# Altium schematic sheet 01_Shield_Ethernet_V1.0.SchDoc
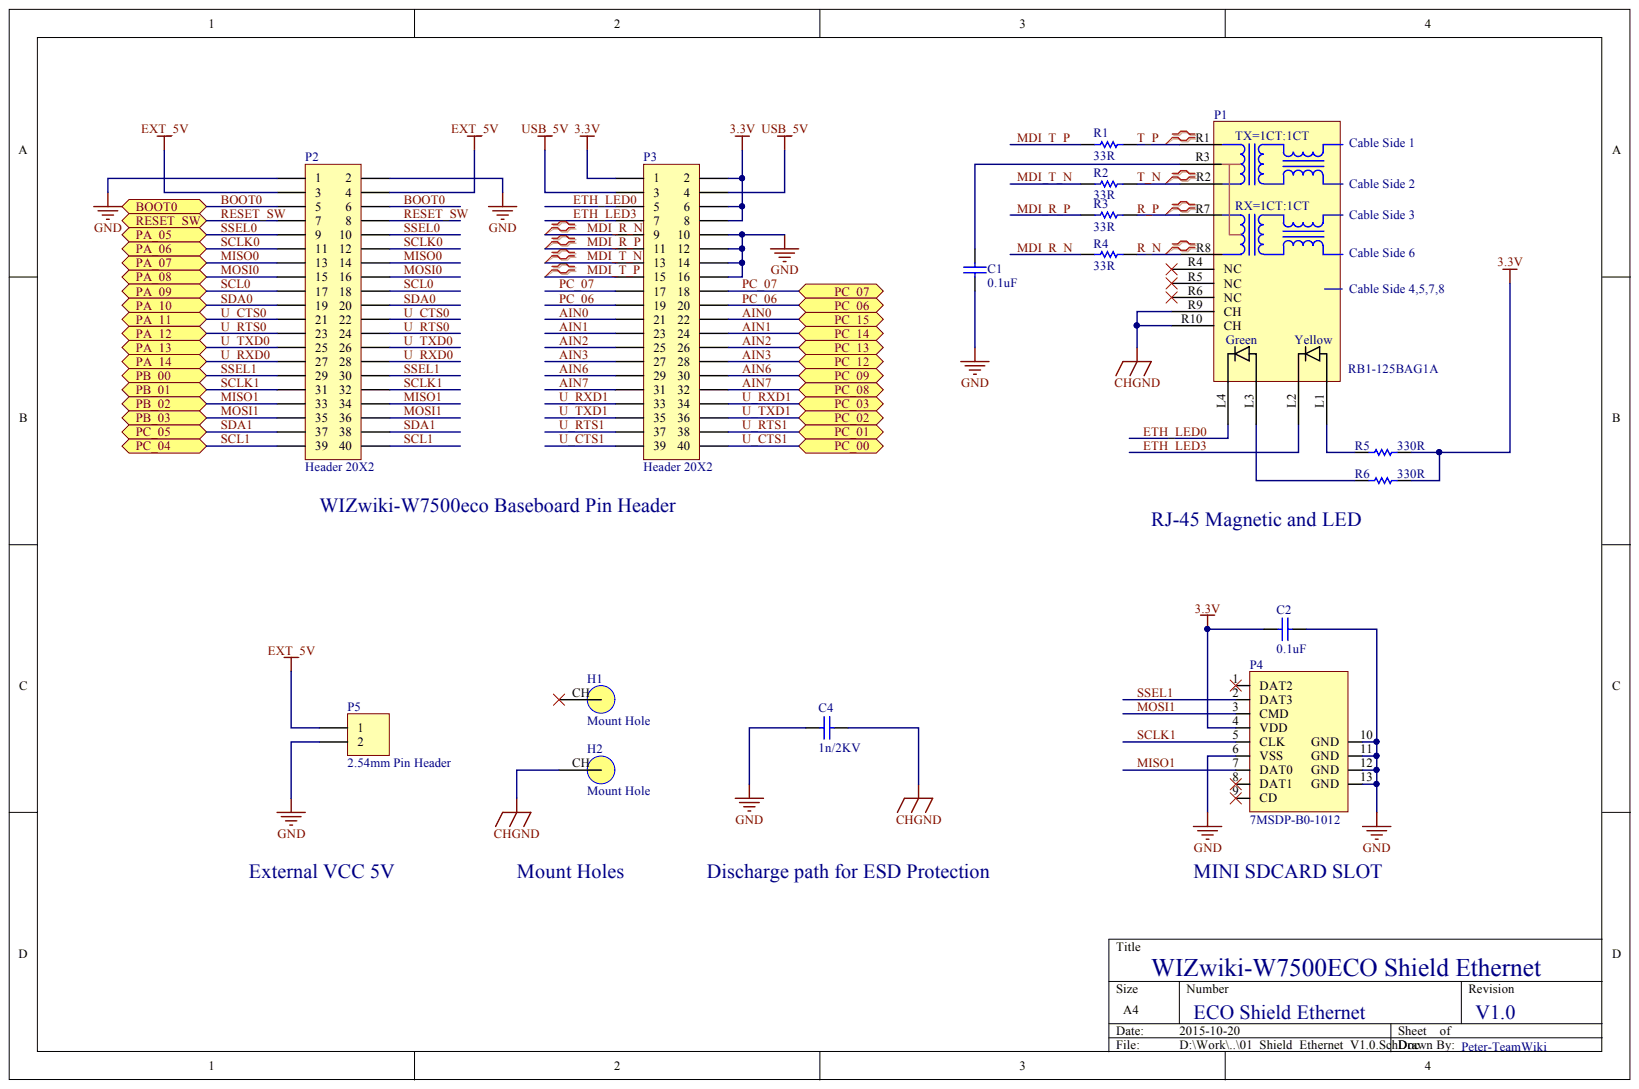
[diagram p0: 01_Shield_Ethernet_V1.0.SchDoc]

## Parts
C1  0.1uF  [CAP-0603]
C2  0.1uF  [CAP-0603]
C4  1n/2KV  [CAP-1808]
H1  Mount Hole
H2  Mount Hole
P1  RB1-125BAG1A  [RJ45-125BAG1A]
P2  Header 20X2  [IDC-2X20]
P3  Header 20X2  [IDC-2X20]
P4  7MSDP-B0-1012  [7MSDP-B0-1012]
P5  2.54mm Pin Header  [IDC-1X2]
R1  33R  [RES-0603]
R2  33R  [RES-0603]
R3  33R  [RES-0603]
R4  33R  [RES-0603]
R5  330R  [RES-0603]
R6  330R  [RES-0603]

## Ports
BOOT0, PA_05, PA_06, PA_07, PA_08, PA_09, PA_10, PA_11, PA_12, PA_13, PA_14, PB_00, PB_01, PB_02, PB_03, PC_00, PC_01, PC_02, PC_03, PC_04, PC_05, PC_06, PC_07, PC_08, PC_09, PC_12, PC_13, PC_14, PC_15, RESET_SW

## Nets
3.3V: C2.2 P3.1 P3.2 P3.6 P3.8 P4.4(VDD) R5.1 R6.1
CHGND: C4.2 H2.CH P1.R9(CH) P1.R10(CH)
EXT_5V: P2.3 P2.4 P5.1
GND: C1.1 C2.1 C4.1 P2.1 P2.2 P3.10 P3.12 P3.14 P3.16 P4.6(VSS) P4.10(GND) P4.11(GND) P4.12(GND) P4.13(GND) P5.2
USB_5V: P3.3 P3.4
AIN0: P3.21 P3.22 ; port PC_15
AIN1: P3.23 P3.24 ; port PC_14
AIN2: P3.25 P3.26 ; port PC_13
AIN3: P3.27 P3.28 ; port PC_12
AIN6: P3.29 P3.30 ; port PC_09
AIN7: P3.31 P3.32 ; port PC_08
BOOT0: P2.5 P2.6 ; port BOOT0
ETH_LED0: P1.L4 P3.5
ETH_LED3: P1.L2 P3.7
MDI_R_N: P3.9 R4.1
MDI_R_P: P3.11 R3.1
MDI_T_N: P3.13 R2.1
MDI_T_P: P3.15 R1.1
MISO0: P2.13 P2.14 ; port PA_07
MISO1: P2.33 P2.34 P4.7(DAT0) ; port PB_02
MOSI0: P2.15 P2.16 ; port PA_08
MOSI1: P2.35 P2.36 P4.3(CMD) ; port PB_03
PC_06: P3.19 P3.20 ; port PC_06
PC_07: P3.17 P3.18 ; port PC_07
R_N: P1.R8 R4.2
R_P: P1.R7 R3.2
RESET_SW: P2.7 P2.8 ; port RESET_SW
SCL0: P2.17 P2.18 ; port PA_09
SCL1: P2.39 P2.40 ; port PC_04
SCLK0: P2.11 P2.12 ; port PA_06
SCLK1: P2.31 P2.32 P4.5(CLK) ; port PB_01
SDA0: P2.19 P2.20 ; port PA_10
SDA1: P2.37 P2.38 ; port PC_05
SSEL0: P2.9 P2.10 ; port PA_05
SSEL1: P2.29 P2.30 P4.2(DAT3) ; port PB_00
T_N: P1.R2 R2.2
T_P: P1.R1 R1.2
U_CTS0: P2.21 P2.22 ; port PA_11
U_CTS1: P3.39 P3.40 ; port PC_00
U_RTS0: P2.23 P2.24 ; port PA_12
U_RTS1: P3.37 P3.38 ; port PC_01
U_RXD0: P2.27 P2.28 ; port PA_14
U_RXD1: P3.33 P3.34 ; port PC_03
U_TXD0: P2.25 P2.26 ; port PA_13
U_TXD1: P3.35 P3.36 ; port PC_02
NetC1_2: C1.2 P1.R3
NetP1_L1: P1.L1 R5.2
NetP1_L3: P1.L3 R6.2

Not connected: H1 CH; P1 R4(NC) R5(NC) R6(NC); P4 1(DAT2) 8(DAT1) 9(CD)

## Library data of the parts
C1 C2 = CAP-0603: Ceramic CAP 0603(1608); Component Kind: Standard; Library Name: Scott_lib.IntLib
C4 = CAP-1808: Ceramic CAP 1808(4520); Component Kind: Standard; Library Name: Scott_lib.IntLib
P1 = RJ-45 MAGNETICS AND LEDS RB1-125BAG1A: Component Kind: Standard; Library Name: peters.SchLib
P2 P3 = Header 20X2: Header, 20-Pin, Dual row, 2.54mm; Component Kind: Standard; LatestRevisionDate: 17-Jul-2002; LatestRevisionNote: Re-released for DXP Platform.; Library Name: Miscellaneous Connectors.IntLib; Publisher: Altium Limited
P4 = 7MSDP-B0-1012: Micro SD Card Socket; Component Kind: Standard; Library Name: Scott_lib.IntLib
P5 = MHDR1X2: Header, 2-Pin; Component Kind: Standard; LatestRevisionDate: 17-Jul-2002; LatestRevisionNote: Re-released for DXP Platform.; Library Name: Miscellaneous Connectors.IntLib; Publisher: Altium Limited
R1-R6 = RES-0603: Component Kind: Standard; Description: RES-0603; Library Name: Scott_lib.IntLib
H1 H2 = Mount Hole: Component Kind: Standard; Library Name: peters.SchLib
